# Altium schematic sheet 01_Power.SchDoc
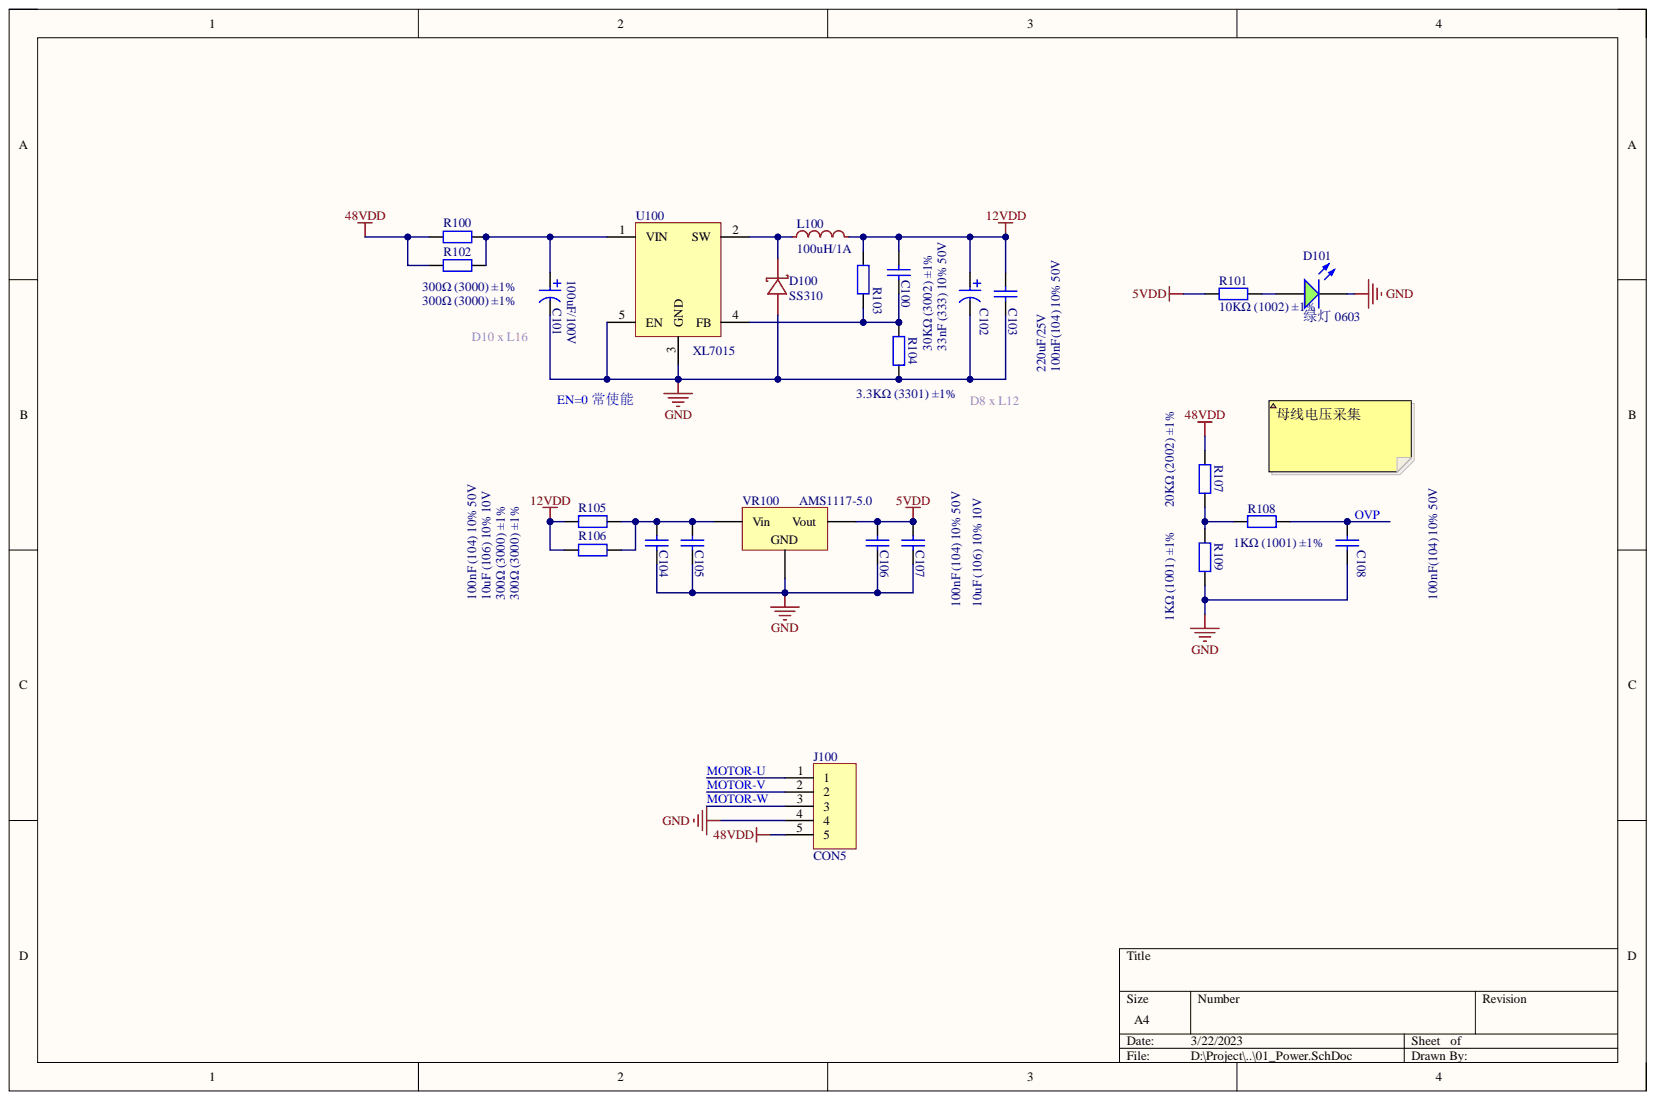
[diagram p0: 01_Power.SchDoc]

## Parts
C100  33nF (333) 10% 50V  [0603_C]
C101  100uF/100V  [CAP_D10_L16]
C102  220uF/25V  [CAP_D8_L12]
C103  100nF(104) 10% 50V  [0805_C]
C104  100nF (104) 10% 50V  [0603_C]
C105  10uF (106) 10% 10V  [0603_C]
C106  100nF (104) 10% 50V  [0603_C]
C107  10uF (106) 10% 10V  [0603_C]
C108  100nF(104) 10% 50V  [0805_C]
D100  SS310  [SS310]
D101  绿灯 0603  Package: 0603  [0603_LED_S1]
J100  CON5  [7.62-5P 端子]
L100  100uH/1A  [L_D9.5_L12]
R100  300Ω (3000) ±1%  [1206_R]
R101  10KΩ (1002) ±1%  [0603_R]
R102  300Ω (3000) ±1%  [1206_R]
R103  30KΩ (3002) ±1%  [0603_R]
R104  3.3KΩ (3301) ±1%  [0603_R]
R105  300Ω (3000) ±1%  [1206_R]
R106  300Ω (3000) ±1%  [1206_R]
R107  20KΩ (2002) ±1%  [0805_R]
R108  1KΩ (1001) ±1%  [0805_R]
R109  1KΩ (1001) ±1%  [0805_R]
U100  XL7015  [XL7015]
VR100  AMS1117-5.0  [SOT-223]

## Nets
5VDD: C106.2 C107.2 R101.2 VR100.2
12VDD: C100.2 C102.1 C103.2 L100.2 R103.1 R105.1 R106.1
48VDD: J100.5 R100.1 R102.1 R107.2
GND: C101.2 C102.2 C103.1 C104.1 C105.1 C106.1 C107.1 C108.1 D100.2 D101.K J100.4 R104.1 R109.1 U100.3(GND) U100.5(EN) VR100.1
MOTOR-U: J100.1
MOTOR-V: J100.2
MOTOR-W: J100.3
OVP: C108.2 R108.1
NetC100_1: C100.1 R103.2 R104.2 U100.4(FB)
NetC101_1: C101.1 R100.2 R102.2 U100.1(VIN)
NetC104_2: C104.2 C105.2 R105.2 R106.2 VR100.3
NetD100_1: D100.1 L100.1 U100.2(SW)
NetD101_A: D101.A R101.1
NetR107_1: R107.1 R108.2 R109.2

## Library data of the parts
C100 = 0603 33nF (333) 10% 50V: 贴片电容; Component Kind: Standard; ComponentLink1Description: 供应商链接; ComponentLink1URL: http://www.szlcsc.com/product/details_21829.html; Library Name: Miscellaneous Devices LC.IntLib; Package: 0603; Supplier: LC; SuppliersPartNumber: C21117
C101 C102 = CAP2: 电解电容; Component Kind: Standard; Library Name: Schlibv2.SchLib
C103 C108 = 0805 100nF(104) 10% 50V: 贴片电容; Component Kind: Standard; ComponentLink1Description: 供应商链接; ComponentLink1URL: http://www.szlcsc.com/product/details_50686.html; Library Name: Miscellaneous Devices LC.IntLib; Package: 0805; Supplier: LC; SuppliersPartNumber: C49678
C104 C106 = 0603 100nF (104) 10% 50V: 贴片电容; Component Kind: Standard; ComponentLink1Description: 供应商链接; ComponentLink1URL: http://www.szlcsc.com/product/details_15331.html; Library Name: Miscellaneous Devices LC.IntLib; Package: 0603; Supplier: LC; SuppliersPartNumber: C14663
C105 C107 = 0603 10uF (106) 10% 10V: 贴片电容; Component Kind: Standard; ComponentLink1Description: 供应商链接; ComponentLink1URL: http://www.szlcsc.com/product/details_20411.html; Library Name: Miscellaneous Devices LC.IntLib; Package: 0603; Supplier: LC; SuppliersPartNumber: C19702
D101 = 0603 绿灯: 发光二极管; Component Kind: Standard; ComponentLink1Description: 供应商链接; ComponentLink1URL: http://www.szlcsc.com/product/details_73152.html; Library Name: Miscellaneous Devices LC.IntLib; Supplier: LC; SuppliersPartNumber: C72043
L100 = L: Component Kind: Standard; Library Name: Schlibv2.SchLib
R100 R102 R105 R106 = 1206 300Ω (3000) 1%: 贴片电阻; Component Kind: Standard; ComponentLink1Description: 供应商链接; ComponentLink1URL: http://www.szlcsc.com/product/details_18575.html; Library Name: Miscellaneous Devices LC.IntLib; Package: 1206; Supplier: LC; SuppliersPartNumber: C17887
R101 = 0603 10KΩ (1002) 1%: 贴片电阻; Component Kind: Standard; ComponentLink1Description: 供应商链接; ComponentLink1URL: http://www.szlcsc.com/product/details_26547.html; Library Name: Miscellaneous Devices LC.IntLib; Package: 0603; Supplier: LC; SuppliersPartNumber: C25804
R103 = 0603 30KΩ (3002) 1%: 贴片电阻; Component Kind: Standard; ComponentLink1Description: 供应商链接; ComponentLink1URL: http://www.szlcsc.com/product/details_23711.html; Library Name: Miscellaneous Devices LC.IntLib; Package: 0603; Supplier: LC; SuppliersPartNumber: C22984
R104 = 0603 3.3KΩ (3301) 1%: 贴片电阻; Component Kind: Standard; ComponentLink1Description: 供应商链接; ComponentLink1URL: http://www.szlcsc.com/product/details_23705.html; Library Name: Miscellaneous Devices LC.IntLib; Package: 0603; Supplier: LC; SuppliersPartNumber: C22978
R107 = 0805 20KΩ (2002) 1%: 贴片电阻; Component Kind: Standard; ComponentLink1Description: 供应商链接; ComponentLink1URL: http://www.szlcsc.com/product/details_4735.html; Library Name: Miscellaneous Devices LC.IntLib; Package: 0805; Supplier: LC; SuppliersPartNumber: C4328
R108 R109 = 0805 1KΩ (1001) 1%: 贴片电阻; Component Kind: Standard; ComponentLink1Description: 供应商链接; ComponentLink1URL: http://www.szlcsc.com/product/details_18201.html; Library Name: Miscellaneous Devices LC.IntLib; Package: 0805; Supplier: LC; SuppliersPartNumber: C17513
VR100 = SOT-223 AMS1117-5.0: 低压差线性稳压(LDO); Component Kind: Standard; ComponentLink1Description: 供应商链接; ComponentLink1URL: http://www.szlcsc.com/product/details_6653.html; Library Name: Miscellaneous Devices LC.IntLib; Package: SOT-223; Supplier: LC; SuppliersPartNumber: C6187
D100 = SS310: Component Kind: Standard; Library Name: Schlibv2.SchLib
J100 = CON5: Component Kind: Standard; Library Name: RayPCB20210502.SchLib
U100 = XL7015: Component Kind: Standard; Library Name: Schlibv2.SchLib
